# Altium schematic sheet power.SchDoc
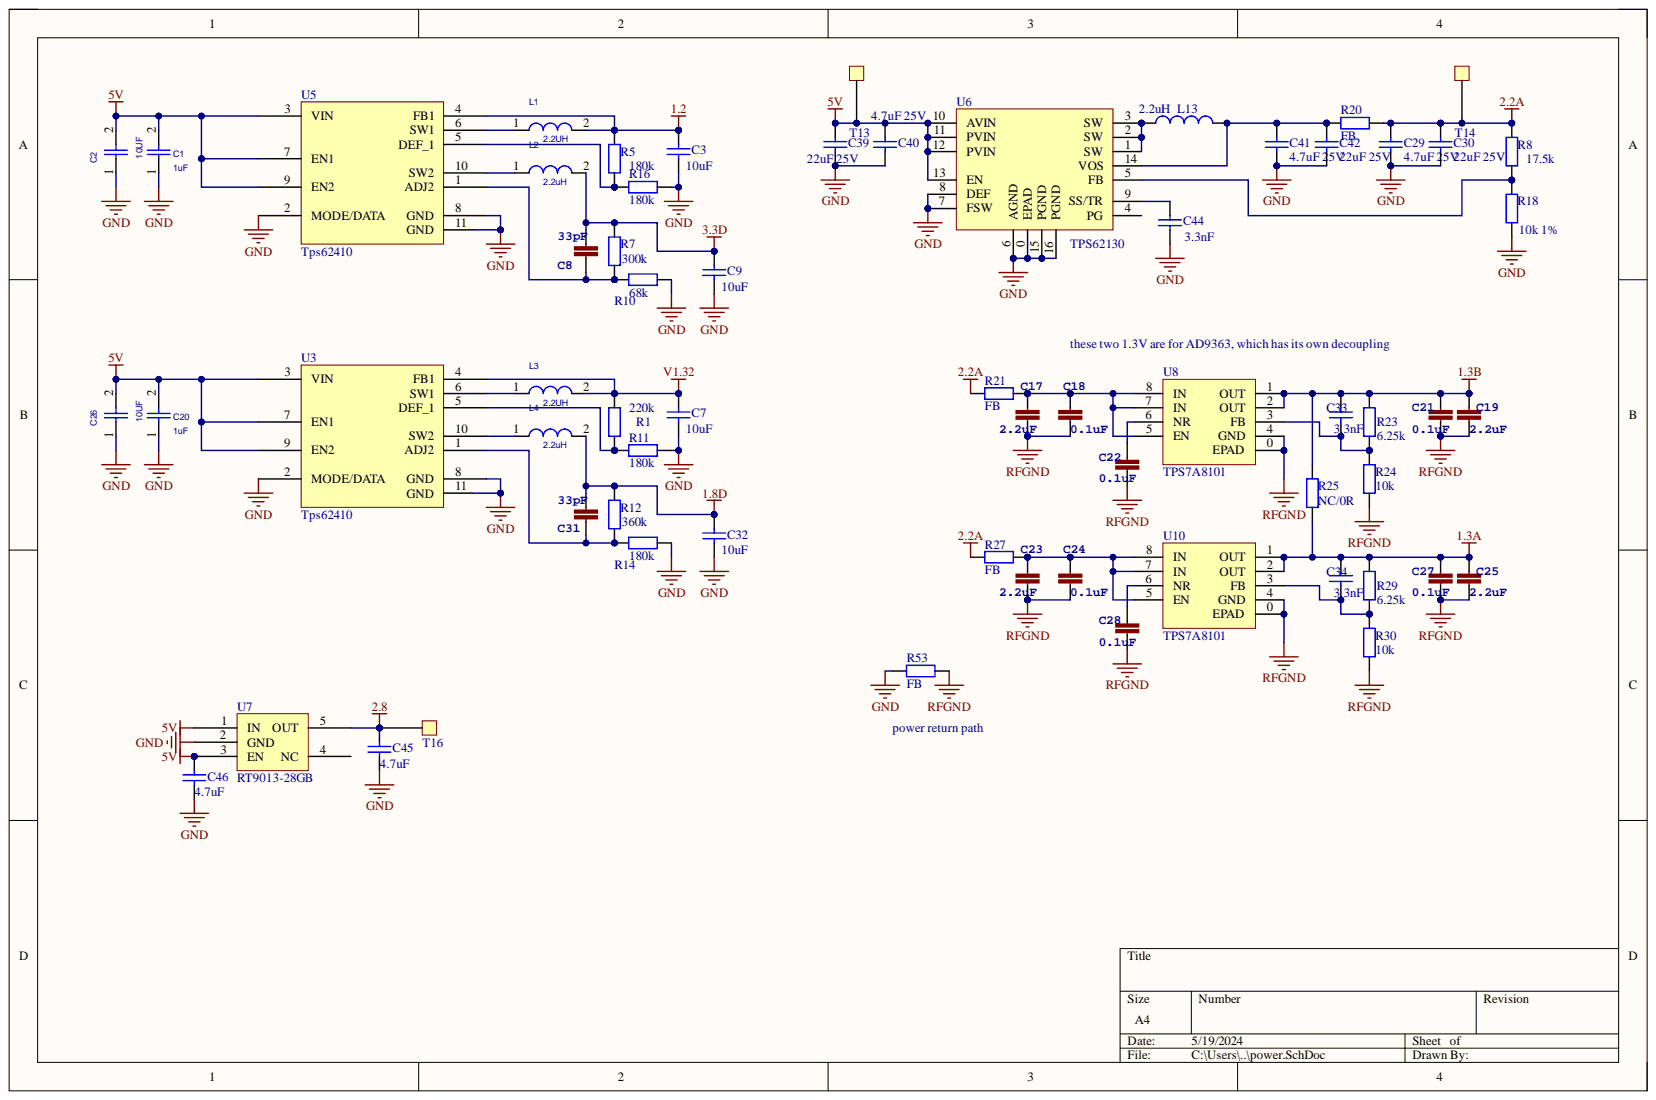
[diagram p0: power.SchDoc]

## Parts
C1  1uF  [0603]
C2  10UF  [0805]
C3  10uF  [0805]
C7  10uF  [0805]
C8  33pF  [0402]
C9  10uF  [0805]
C17  2.2uF  [0603]
C18  0.1uF  [0402]
C19  2.2uF  [0603]
C20  1uF  [0603]
C21  0.1uF  [0603]
C22  0.1uF  [0402]
C23  2.2uF  [0603]
C24  0.1uF  [0402]
C25  2.2uF  [0603]
C26  10UF  [0805]
C27  0.1uF  [0603]
C28  0.1uF  [0402]
C29  value 4.7uF 25V  [0603]  (hidden comment: Cap)
C30  value 22uF 25V  [0805]  (hidden comment: Cap)
C31  33pF  [0402]
C32  10uF  [0805]
C33  3.3nF  [0402]
C34  3.3nF  [0402]
C39  value 22uF 25V  [0805]  (hidden comment: Cap)
C40  value 4.7uF 25V  [0603]  (hidden comment: Cap)
C41  value 4.7uF 25V  [0603]  (hidden comment: Cap)
C42  value 22uF 25V  [0805]  (hidden comment: Cap)
C44  3.3nF  [0402]
C45  4.7uF  [0603]
C46  4.7uF  [0603]
L1  2.2UH  [0806]
L2  2.2uH  [0806]
L3  2.2UH  [0806]
L4  2.2uH  [0806]
L13  2.2uH  [L3015]
R1  220k  [0402]
R5  180k  [0402]
R7  300k  [0402]
R8  17.5k  [0402]
R10  68k  [0402]
R11  180k  [0402]
R12  360k  [0402]
R14  180k  [0402]
R16  180k  [0402]
R18  10k 1%  [0402]
R20  FB  [0603]
R21  FB  [0603]
R23  6.25k  [0402]
R24  10k  [0402]
R25  NC/0R  [0603]
R27  FB  [0603]
R29  6.25k  [0402]
R30  10k  [0402]
R53  FB  [0603]
T13  TestPad  [TestPad - 1mm]  (value not drawn)
T14  TestPad  [TestPad - 1mm]  (value not drawn)
T16  TestPad  [TestPad - 1mm]  (value not drawn)
U3  Tps62410  [DFN-10P-3X3]
U5  Tps62410  [DFN-10P-3X3]
U6  TPS62130  [TPS62130]
U7  RT9013-28GB  [SOT23-5]
U8  TPS7A8101  [TPS7A8101]
U10  TPS7A8101  [TPS7A8101]

## Nets
1.2: C3.2 L1.2 R5.1 U5.4(FB1)
1.3A: C25.2 C27.2 C34.1 R25.2 R29.1 U10.1(OUT) U10.2(OUT)
1.3B: C19.2 C21.2 C33.1 R23.1 R25.1 U8.1(OUT) U8.2(OUT)
1.8D: C31.1 C32.2 L4.2 R12.1
2.2A: C29.2 C30.2 R8.1 R20.1 R21.2 R27.2 T14.1
2.8: C45.2 T16.1 U7.5(OUT)
3.3D: C8.1 C9.2 L2.2 R7.1
5V: C1.2 C2.2 C20.2 C26.2 C39.1 C40.1 C46.2 T13.1 U3.3(VIN) U3.7(EN1) U3.9(EN2) U5.3(VIN) U5.7(EN1) U5.9(EN2) U6.10(AVIN) U6.11(PVIN) U6.12(PVIN) U6.13(EN) U7.1(IN) U7.3(EN)
GND: C1.1 C2.1 C3.1 C7.1 C9.1 C20.1 C26.1 C29.1 C30.1 C32.1 C39.2 C40.2 C41.1 C42.1 C44.2 C45.1 C46.1 R10.2 R11.2 R14.2 R16.2 R18.2 R53.2 U3.2(MODE/DATA) U3.8(GND) U3.11(GND) U5.2(MODE/DATA) U5.8(GND) U5.11(GND) U6.0(EPAD) U6.6(AGND) U6.7(FSW) U6.8(DEF) U6.15(PGND) U6.16(PGND) U7.2(GND)
RFGND: C17.1 C18.1 C19.1 C21.1 C22.1 C23.1 C24.1 C25.1 C27.1 C28.1 R24.2 R30.2 R53.1 U8.0(EPAD) U8.4(GND) U10.0(EPAD) U10.4(GND)
V1.32: C7.2 L3.2 R1.1 U3.4(FB1)
NetC8_2: C8.2 R7.2 R10.1 U5.1(ADJ2)
NetC17_2: C17.2 C18.2 R21.1 U8.5(EN) U8.7(IN) U8.8(IN)
NetC22_2: C22.2 U8.6(NR)
NetC23_2: C23.2 C24.2 R27.1 U10.5(EN) U10.7(IN) U10.8(IN)
NetC28_2: C28.2 U10.6(NR)
NetC31_2: C31.2 R12.2 R14.1 U3.1(ADJ2)
NetC33_2: C33.2 R23.2 R24.1 U8.3(FB)
NetC34_2: C34.2 R29.2 R30.1 U10.3(FB)
NetC41_2: C41.2 C42.2 L13.2 R20.2 U6.14(VOS)
NetC44_1: C44.1 U6.9(SS/TR)
NetL1_1: L1.1 U5.6(SW1)
NetL2_1: L2.1 U5.10(SW2)
NetL3_1: L3.1 U3.6(SW1)
NetL4_1: L4.1 U3.10(SW2)
NetL13_1: L13.1 U6.1(SW) U6.2(SW) U6.3(SW)
NetR1_2: R1.2 R11.1 U3.5(DEF_1)
NetR5_2: R5.2 R16.1 U5.5(DEF_1)
NetR8_2: R8.2 R18.1 U6.5(FB)

Not connected: U6 4(PG); U7 4(NC)

## Library data of the parts
C1 C2 C20 C26 = CAP/0402: 1st Part Field: 0402; Component Kind: Standard
C3 C7 C9 C29 C30 C32-C34 C39 C42 C44-C46 = Cap: Capacitor; Component Kind: Standard; LatestRevisionDate: 17-Jul-2002; LatestRevisionNote: Re-released for DXP Platform.; Library Name: RTC6705.SchLib; PackageDescription: Radial Cap, Thru-Hole; 2 Leads; 0.3 in Pin Spacing; PackageReference: RAD-0.3; Published: 8-Jun-2000; Signal Integrity: Cap; Simulation: CAP; Publisher: Altium Limited
C8 C17-C19 C21-C25 C27 C28 C31 = CAP0402-CAP: <b>Capacitor</b> Standard 0603 ceramic capacitor, and 0.1" leaded capacitor.; PARTNO: CC0402ZRY5V7BB104; Component Kind: Standard
  C17 C19 C23 C25: Library Name: pixhawk2.IntLib
C40 C41 = Cap: Capacitor; Value: 4.7uF 25V; Component Kind: Standard; LatestRevisionDate: 17-Jul-2002; LatestRevisionNote: Re-released for DXP Platform.; Library Name: RTC6705.SchLib; PackageDescription: Radial Cap, Thru-Hole; 2 Leads; 0.3 in Pin Spacing; PackageReference: RAD-0.3; Published: 8-Jun-2000; Signal Integrity: Cap; Simulation: CAP; Publisher: Altium Limited
L1-L4 = L/2.5*2.0: Component Kind: Standard
L13 = Inductor: Code_IEC: 1005; Code_JEITA: 0402; Component Kind: Standard; Description: Inductor; LatestRevisionDate: 29-May-2009; LatestRevisionNote: IPC-7351 Footprint Added.; Library Name: Miscellaneous Devices.IntLib; PackageDescription: Chip Inductor; PackageReference: 0402-A; Published: 8-Jun-2000; Signal Integrity: Inductor; Simulation: INDUCTOR; Publisher: Altium Limited
R1 R5 R7 R8 R10-R12 R14 R16 R18 = Res2: Resistor; Component Kind: Standard; LatestRevisionDate: 17-Jul-2002; LatestRevisionNote: Re-released for DXP Platform.; Library Name: RTC6705.SchLib; PackageDescription: Axial Device, Thru-Hole; 2 Leads; 0.4 in Pin Spacing; PackageReference: AXIAL-0.4; Published: 8-Jun-2000; Signal Integrity: Res; Simulation: RESISTOR; Publisher: Altium Limited
R20 R21 R23-R25 R27 R29 R30 R53 = Res2: Resistor; Value: 0Ohm; Component Kind: Standard; LatestRevisionDate: 17-Jul-2002; LatestRevisionNote: Re-released for DXP Platform.; Library Name: Miscellaneous Devices.IntLib; PackageDescription: Axial Device, Thru-Hole; 2 Leads; 0.4 in Pin Spacing; PackageReference: AXIAL-0.4; Published: 8-Jun-2000; Signal Integrity: Res; Simulation: RESISTOR; Publisher: Altium Limited
U7 = LP5907: Component Kind: Standard; Library Name: RTC6705.SchLib
T13 T14 T16 = TestPad: Component Kind: Standard; Library Name: sch.SchLib
U3 U5 = Tps62410: Component Kind: Standard; Library Name: Euler.SchLib
U6 = TPS62130: Component Kind: Standard; Library Name: sch.SchLib
U8 U10 = TPS7A8101: Component Kind: Standard; Library Name: F4SDR.SchLib
